# Altium schematic sheet 03.Display.SchDoc
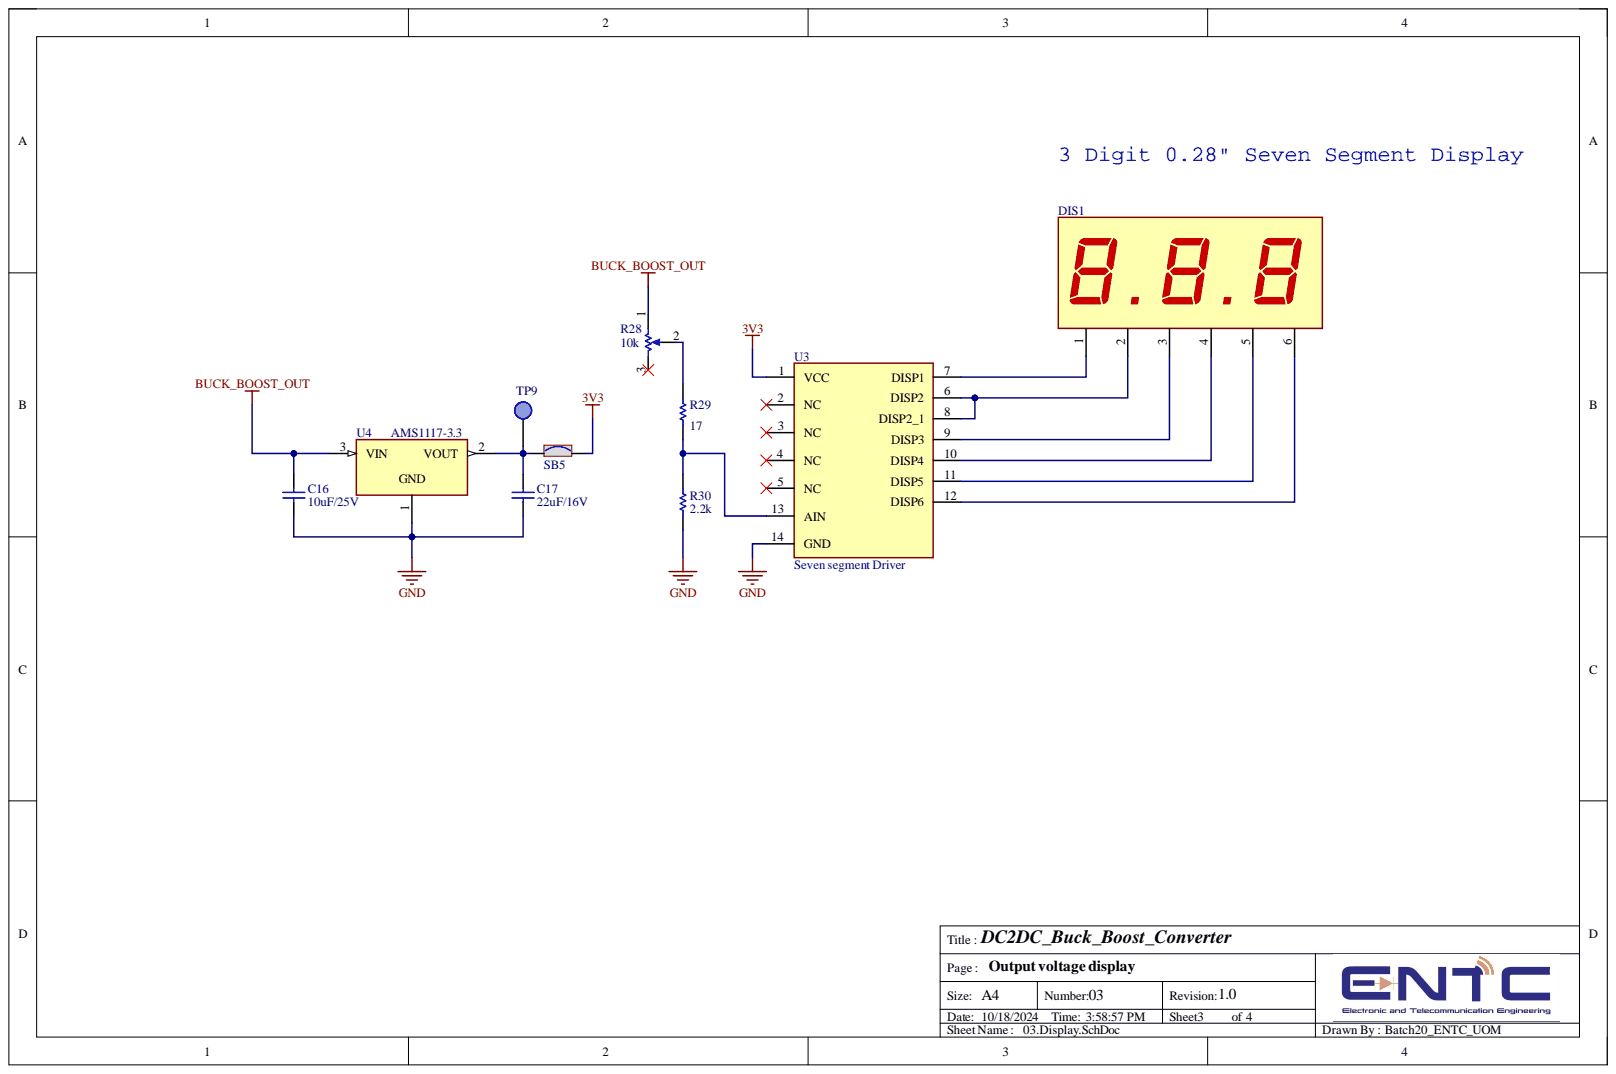
[diagram p0: 03.Display.SchDoc]

## Parts
C16  10uF/25V  [cap0805]
C17  22uF/16V  [cap0805]
DIS1  6pin 3 digit seven segment display module  [7Segment-0.28inch]  (value not drawn)
R28  10k  [TRIM_TC33X-2-201G]
R29  17  [res0603]
R30  2.2k  [RES0805]
SB5  SB_ON  [SOLDER-BRIDGE_R1210-0R]  (value not drawn)
TP9  (no value)  [tp]  (no BOM)
U3  Seven segment Driver  [SOIC127P600X175-14N]
U4  AMS1117-3.3  [SOT229P700X180-4N]

## Nets
3V3: SB5.2 U3.1(VCC)
BUCK_BOOST_OUT: C16.1 R28.1 U4.3
GND: C16.2 C17.2 R30.1 U3.14(GND) U4.1
NetC17_1: C17.1 SB5.1 TP9.1 U4.2
NetDIS1_1: DIS1.1 U3.7(DISP1)
NetDIS1_2: DIS1.2 U3.6(DISP2) U3.8(DISP2_1)
NetDIS1_3: DIS1.3 U3.9(DISP3)
NetDIS1_4: DIS1.4 U3.10(DISP4)
NetDIS1_5: DIS1.5 U3.11(DISP5)
NetDIS1_6: DIS1.6 U3.12(DISP6)
NetR28_2: R28.2 R29.2
NetR29_1: R29.1 R30.2 U3.13(AIN)

Not connected: R28 3; U3 2(NC) 3(NC) 4(NC) 5(NC)

## Library data of the parts
C16 C17 = Cap: Capacitor; Component Kind: Standard; Library Name: Altium_Main_Library.SchLib
DIS1 = SevenSegment6Pin: Component Kind: Standard; Library Name: DC2DC_Buck_Boost_Converter_SchLib.SchLib
R28 = RPot: Potentiometer; Component Kind: Standard; Library Name: DC2DC_Buck_Boost_Converter_SchLib.SchLib
R29 R30 = Res: Resistor; Component Kind: Standard; Library Name: Altium_Main_Library.SchLib
SB5 = SB_ON: Solder Bridge ON; MP: PMR03EZPJ000; Component Kind: Standard; Library Name: Altium_Main_Library.SchLib
TP9 = TP: Test Point; Library Name: Altium_Main_Library.SchLib
U3 = Seven segment Driver: ADC/MUX/Binary2BCD/BCDto7Segment; MP: S-8254AAEFT-TB-G; Component Kind: Standard; Library Name: DC2DC_Buck_Boost_Converter_SchLib.SchLib
U4 = AMS1117-3.3: Sot223/Pkg 1-Amp 3.3-Volt Low Drop Out Voltage Regulatator; Component Kind: Standard; Library Name: DC2DC_Buck_Boost_Converter_SchLib.SchLib; MP: AMS1117-3.3
